# Altium schematic sheet MainSchematic.SchDoc(MainSchematic)
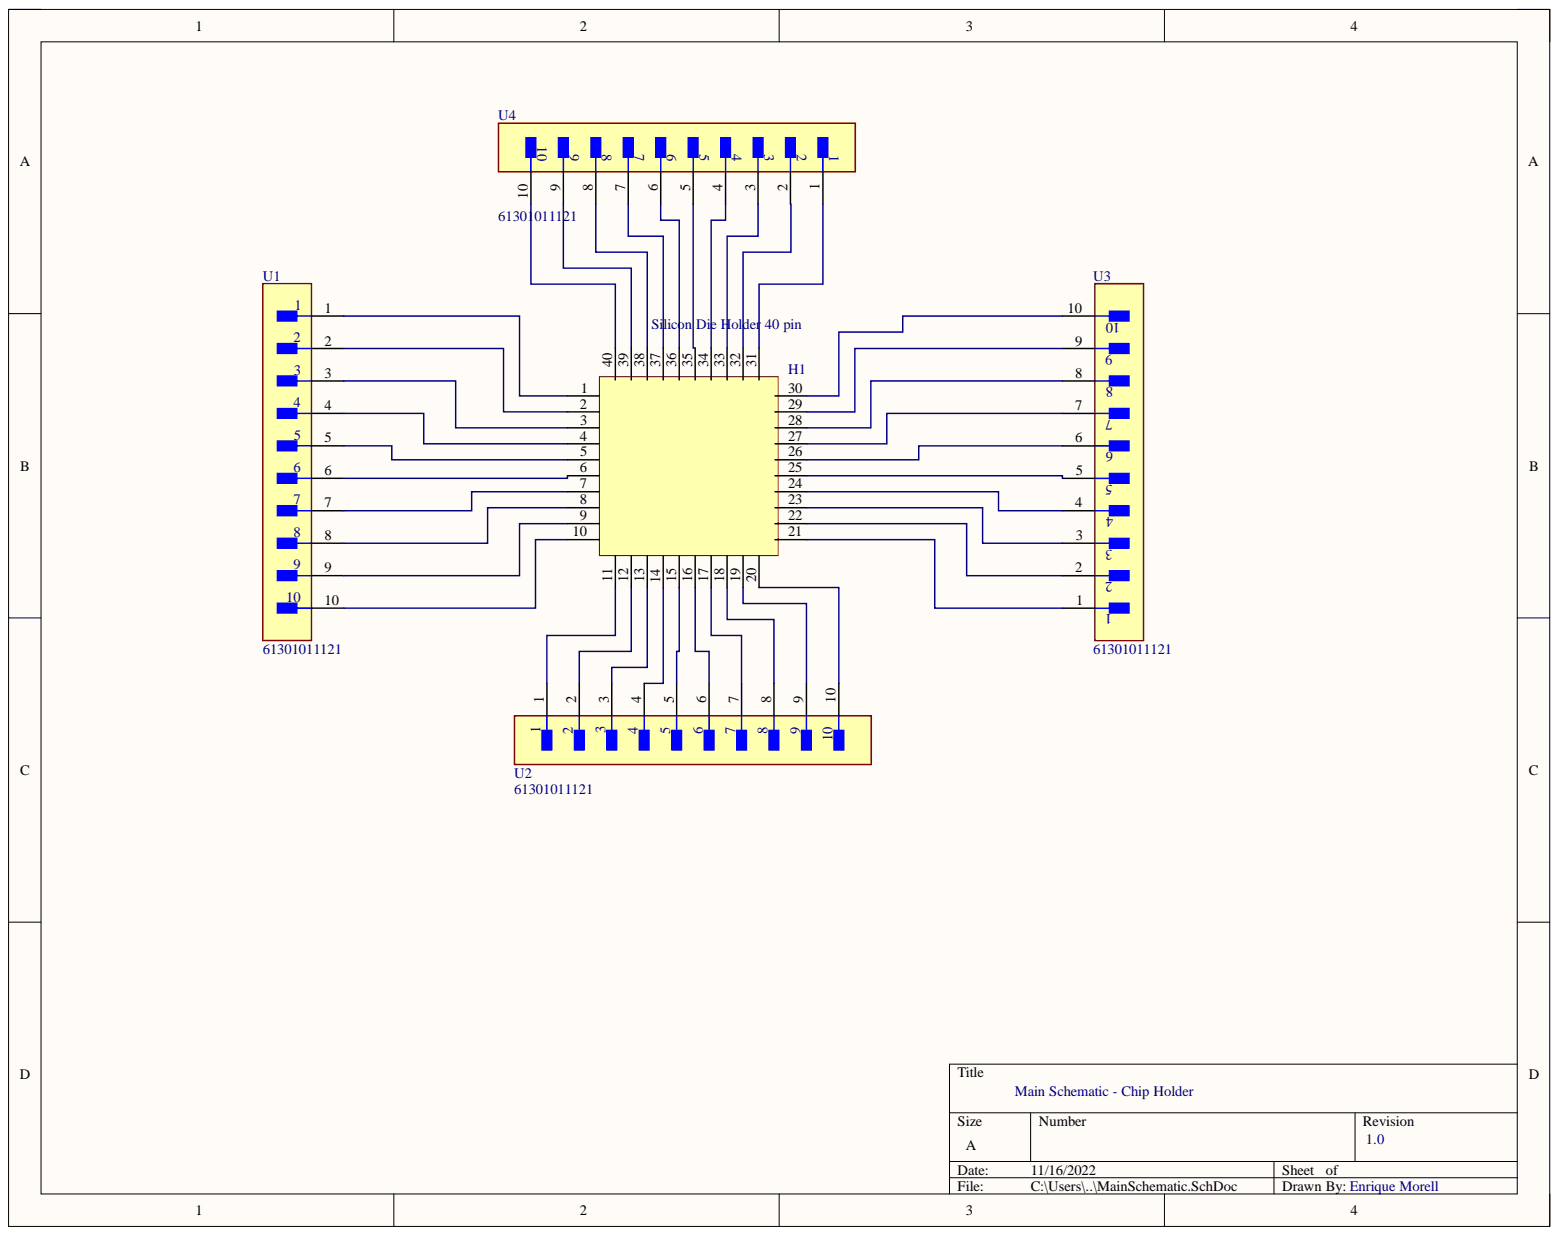
[diagram p0: MainSchematic.SchDoc]

## Parts
H1  Silicon Die Holder 40 pin  [CQFP200P2508X2508X310-40N]
U1  61301011121  [FP-61301011121-MFG]
U2  61301011121  [FP-61301011121-MFG]
U3  61301011121  [FP-61301011121-MFG]
U4  61301011121  [FP-61301011121-MFG]

## Nets
NetH1_1: H1.1 U1.1
NetH1_2: H1.2 U1.2
NetH1_3: H1.3 U1.3
NetH1_4: H1.4 U1.4
NetH1_5: H1.5 U1.5
NetH1_6: H1.6 U1.6
NetH1_7: H1.7 U1.7
NetH1_8: H1.8 U1.8
NetH1_9: H1.9 U1.9
NetH1_10: H1.10 U1.10
NetH1_11: H1.11 U2.1
NetH1_12: H1.12 U2.2
NetH1_13: H1.13 U2.3
NetH1_14: H1.14 U2.4
NetH1_15: H1.15 U2.5
NetH1_16: H1.16 U2.6
NetH1_17: H1.17 U2.7
NetH1_18: H1.18 U2.8
NetH1_19: H1.19 U2.9
NetH1_20: H1.20 U2.10
NetH1_21: H1.21 U3.1
NetH1_22: H1.22 U3.2
NetH1_23: H1.23 U3.3
NetH1_24: H1.24 U3.4
NetH1_25: H1.25 U3.5
NetH1_26: H1.26 U3.6
NetH1_27: H1.27 U3.7
NetH1_28: H1.28 U3.8
NetH1_29: H1.29 U3.9
NetH1_30: H1.30 U3.10
NetH1_31: H1.31 U4.1
NetH1_32: H1.32 U4.2
NetH1_33: H1.33 U4.3
NetH1_34: H1.34 U4.4
NetH1_35: H1.35 U4.5
NetH1_36: H1.36 U4.6
NetH1_37: H1.37 U4.7
NetH1_38: H1.38 U4.8
NetH1_39: H1.39 U4.9
NetH1_40: H1.40 U4.10

## Library data of the parts
H1 = Silicon Die Holder 40 pin 20mm: Database Table Name: Silicon Die Holders; Component Kind: Standard; Description: Silicon die holder 40 pin 20mm; Library Name: Celestial Library Database.DbLib; Part Number: Silicon Die Holder 40 pin 20mm
U1-U4 = CMP-1502-01082-3: CONN HEADER VERT 10POS 2.54MM; Current Rating: 3A; Gender: Male; Insulation Resistance: 1GR; Number of Contacts: 10; Voltage Rating: 250V; Color: Black; Component Kind: Standard; Connector Type: Header; Contact Finish - Mating: GOLD; Contact Gender: Male; Contact Material: Copper Alloy; Contact Mating Length: 0.236inch; Contact Plating: Gold; Contact Shape: Square; Contact Type: Male Pin; Fastening Type: Pull; Flammability Rating: UL94 V-0; Height: 11.54mm; Housing Material: PBT; Insulation Color: Black; Insulation Material: Nylon; Length: 2.54mm; Library Name: Altium Content Vault; Max Operating Temperature: 105°C; Min Operating Temperature: -40°C; Mount: Through Hole; Number of Positions: 10; Number of Rows: 1; Orientation: Straight; Package Quantity: 1000; Packaging: Bulk; Pitch: 2.54mm; RoHS Compliant: Yes; Series: WR-PHD; Termination: Solder; Width: 25.4mm
